# Altium schematic sheet Controller_Board_Interface.SchDoc(Controller_Board_Interface)
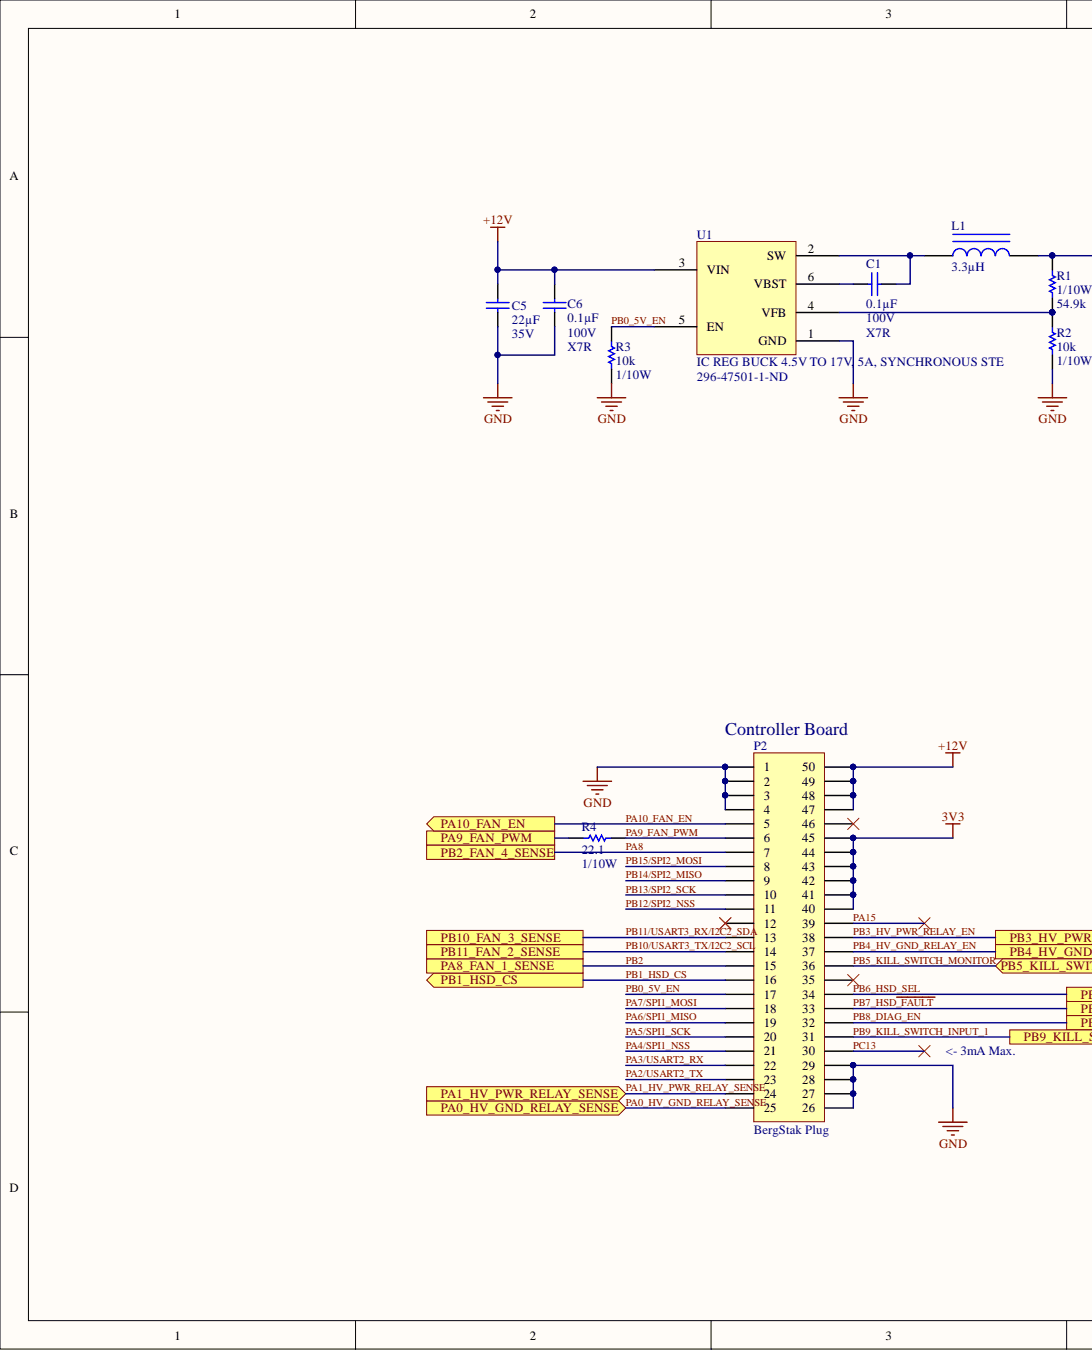
[diagram p0: Controller_Board_Interface.SchDoc, left]
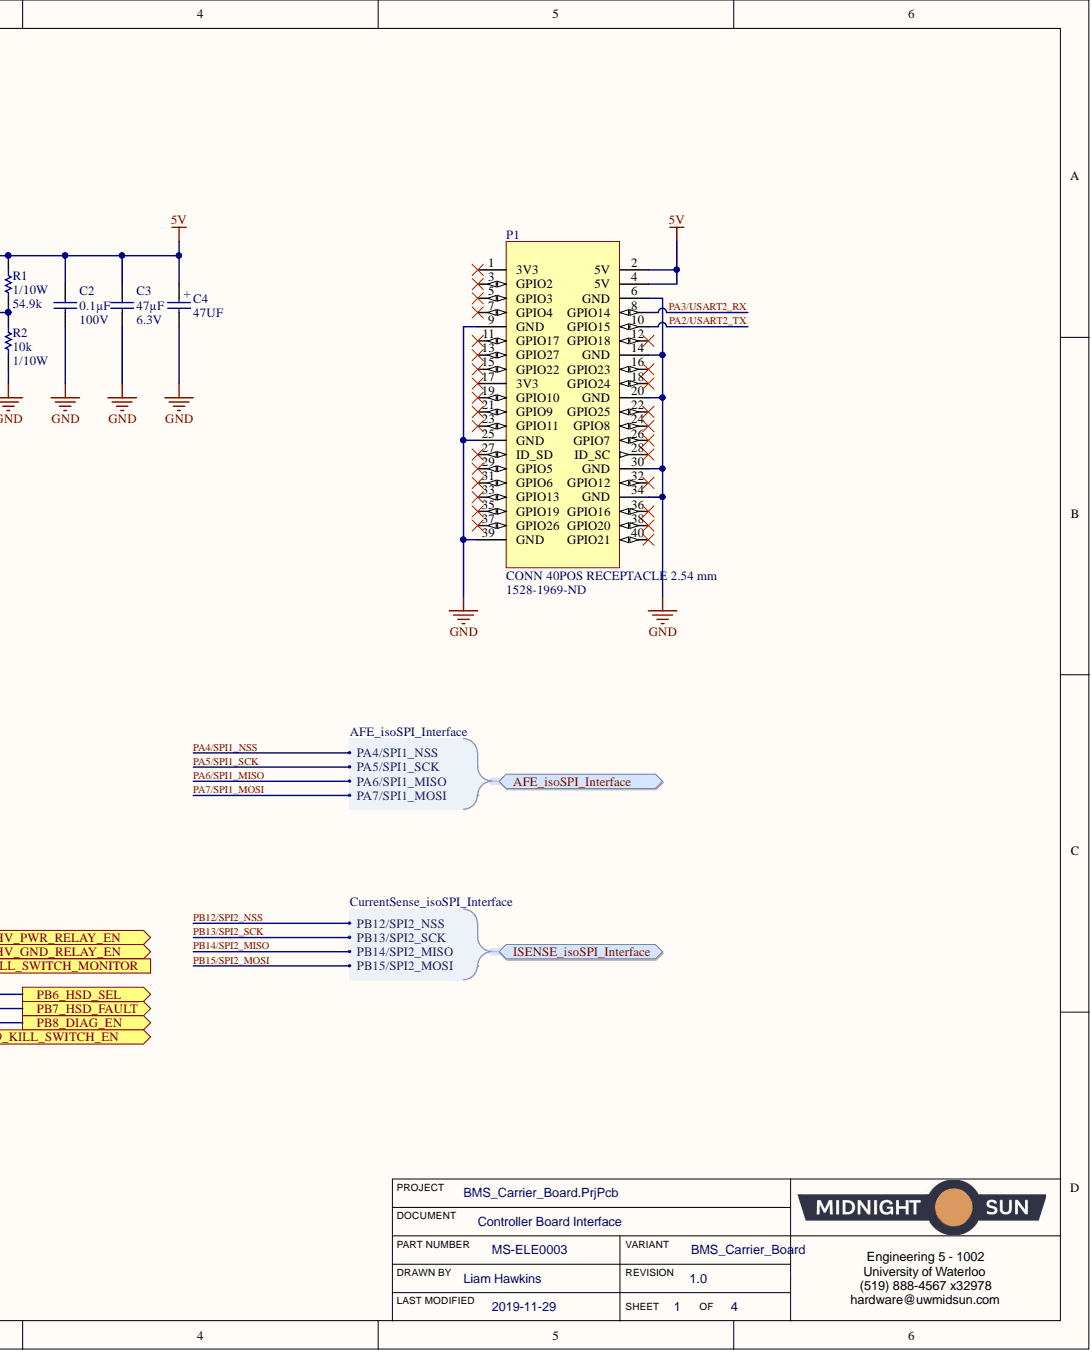
[diagram p1: Controller_Board_Interface.SchDoc, right]

## Parts
C1  Voltage: 100V  Capacitance: 0.1µF  [CAP, 0805]  (hidden comment: CAP CER 0.1UF 100V 10% X7R 0805)
C2  Voltage: 100V  Capacitance: 0.1µF  [CAP, 0805]  (hidden comment: CAP CER 0.1UF 100V 10% X7R 0805)
C3  Voltage Rating (DC): 6.3V  [1210]  (hidden comment: CAP CER 47UF 6.3V X7R 1210)
C4  47UF  [CAP ALUM 6.3MM RADIAL SMD]
C5  Voltage Rating (DC): 35V  [CAP, 0805]  (hidden comment: CAP CER 22UF 35V X5R 0805)
C6  Voltage: 100V  Temperature Coefficient: X7R  Capacitance: 0.1µF  [CAP, 0805]  (hidden comment: CAP CER 0.1UF 100V 10% X7R 0805)
L1  Inductance: 3.3µH  [IND 3.3UH 5.2A 20 MOHM SMD VLP8040]  (hidden comment: IND 3.3uH 5.2A 20MOHM SMD)
P1  CONN 40POS RECEPTACLE 2.54 mm  Supplier Part Number 1: 1528-1969-ND  [CONN 40POS RECEPTACLE 2.54 MM]
P2  Series: BergStak Plug  [CONN, Bergstak 50 Pos Plug]  (hidden comment: CONN 50POS Bergstak Plug 0.02")
R1  Resistance (Ohms): 54.9k  Power (Watts): 1/10W  [RES, 0603]  (hidden comment: RES 54.9K OHM 1% 1/10W 0603)
R2  Resistance: 10k  Power: 1/10W  [RES, 0603]  (hidden comment: RES 10K OHM 1% 1/10W 0603)
R3  Resistance: 10k  Power: 1/10W  [RES, 0603]  (hidden comment: RES 10K OHM 1% 1/10W 0603)
R4  Resistance: 22.1  Power: 1/10W  [RES, 0603]  (hidden comment: RES 22.1 OHM 1% 1/10W 0603)
U1  IC REG BUCK 4.5V TO 17V, 5A, SYNCHRONOUS STE  Supplier Part Number 1: 296-47501-1-ND  [IC REG BUCK 4.5V TO 17V, 5A]

## Ports
AFE_isoSPI_Interface, AFE_isoSPI_Interface.PA4/SPI1_NSS, AFE_isoSPI_Interface.PA5/SPI1_SCK, AFE_isoSPI_Interface.PA6/SPI1_MISO, AFE_isoSPI_Interface.PA7/SPI1_MOSI, ISENSE_isoSPI_Interface, ISENSE_isoSPI_Interface.PB12/SPI2_NSS, ISENSE_isoSPI_Interface.PB13/SPI2_SCK, ISENSE_isoSPI_Interface.PB14/SPI2_MISO, ISENSE_isoSPI_Interface.PB15/SPI2_MOSI, PA0_HV_GND_RELAY_SENSE, PA1_HV_PWR_RELAY_SENSE, PA8_FAN_1_SENSE, PA9_FAN_PWM, PA10_FAN_EN, PB1_HSD_CS, PB2_FAN_4_SENSE, PB3_HV_PWR_RELAY_EN, PB4_HV_GND_RELAY_EN, PB5_KILL_SWITCH_MONITOR, PB6_HSD_SEL, PB7_HSD~{_FAULT}, PB8_DIAG_EN, PB9_KILL_SWITCH_EN, PB10_FAN_3_SENSE, PB11_FAN_2_SENSE

## Nets
3V3: P2.40 P2.41 P2.42 P2.43 P2.44 P2.45
5V: C2.1 C3.1 C4.1 L1.2 P1.2(5V) P1.4(5V) R1.1
+12V: C5.1 C6.1 P2.47 P2.48 P2.49 P2.50 U1.3(VIN)
GND: C2.2 C3.2 C4.2 C5.2 C6.2 P1.6(GND) P1.9(GND) P1.14(GND) P1.20(GND) P1.25(GND) P1.30(GND) P1.34(GND) P1.39(GND) P2.1 P2.2 P2.3 P2.4 P2.26 P2.27 P2.28 P2.29 R2.2 R3.2 U1.1(GND)
PA0_HV_GND_RELAY_SENSE: P2.25 ; port PA0_HV_GND_RELAY_SENSE
PA1_HV_PWR_RELAY_SENSE: P2.24 ; port PA1_HV_PWR_RELAY_SENSE
PA2/USART2_TX: P1.10(GPIO15) P2.23
PA3/USART2_RX: P1.8(GPIO14) P2.22
PA4/SPI1_NSS: P2.21 ; port AFE_isoSPI_Interface
PA5/SPI1_SCK: P2.20 ; port AFE_isoSPI_Interface
PA6/SPI1_MISO: P2.19 ; port AFE_isoSPI_Interface
PA7/SPI1_MOSI: P2.18 ; port AFE_isoSPI_Interface
PA8: P2.7 ; port PB2_FAN_4_SENSE
PA9_FAN_PWM: P2.6 R4.2
PA10_FAN_EN: P2.5 ; port PA10_FAN_EN
PA15: P2.39
PB0_5V_EN: P2.17 R3.1 U1.5(EN)
PB1_HSD_CS: P2.16 ; port PB1_HSD_CS
PB2: P2.15 ; port PA8_FAN_1_SENSE
PB3_HV_PWR_RELAY_EN: P2.38 ; port PB3_HV_PWR_RELAY_EN
PB4_HV_GND_RELAY_EN: P2.37 ; port PB4_HV_GND_RELAY_EN
PB5_KILL_SWITCH_MONITOR: P2.36 ; port PB5_KILL_SWITCH_MONITOR
PB6_HSD_SEL: P2.34 ; port PB6_HSD_SEL
PB7_HSD~{_FAULT}: P2.33 ; port PB7_HSD~{_FAULT}
PB8_DIAG_EN: P2.32 ; port PB8_DIAG_EN
PB9_KILL_SWITCH_INPUT_1: P2.31 ; port PB9_KILL_SWITCH_EN
PB10/USART3_TX/I2C2_SCL: P2.14 ; port PB11_FAN_2_SENSE
PB11/USART3_RX/I2C2_SDA: P2.13 ; port PB10_FAN_3_SENSE
PB12/SPI2_NSS: P2.11 ; port ISENSE_isoSPI_Interface
PB13/SPI2_SCK: P2.10 ; port ISENSE_isoSPI_Interface
PB14/SPI2_MISO: P2.9 ; port ISENSE_isoSPI_Interface
PB15/SPI2_MOSI: P2.8 ; port ISENSE_isoSPI_Interface
PC13: P2.30
NetC1_1: C1.1 U1.6(VBST)
NetC1_2: C1.2 L1.1 U1.2(SW)
NetR1_2: R1.2 R2.1 U1.4(VFB)
NetR4_1: R4.1 ; port PA9_FAN_PWM

Not connected: P1 1(3V3) 3(GPIO2) 5(GPIO3) 7(GPIO4) 11(GPIO17) 12(GPIO18) 13(GPIO27) 15(GPIO22) 16(GPIO23) 17(3V3) 18(GPIO24) 19(GPIO10) 21(GPIO9) 22(GPIO25) 23(GPIO11) 24(GPIO8) 26(GPIO7) 27(ID_SD) 28(ID_SC) 29(GPIO5) 31(GPIO6) 32(GPIO12) 33(GPIO13) 35(GPIO19) 36(GPIO16) 37(GPIO26) 38(GPIO20) 40(GPIO21); P2 12 35 46

## Library data of the parts
C1 C2 C6 = CAP CER 0.1UF 100V 10% X7R 0805: 0.1µF ±10% 100V Ceramic Capacitor X7R 0805 (2012 Metric); Manufacturer Part Number 1: GCM21BR72A104KA37L; Manufacturer 1: Murata Electronics North America; Tolerance: ±10%; Thickness (Max): 0.055" (1.40mm); Supplier Part Number 1: 490-4789-1-ND; Supplier 1: Digi-Key; Size / Dimension: 0.079" L x 0.049" W (2.00mm x 1.25mm); Ratings: AEC-Q200; Part Status: Active; Packaging: Cut Tape (CT); Package / Case: 0805 (2012 Metric); Operating Temperature: -55°C ~ 125°C; Mounting Type: Surface Mount, MLCC; Lead Style: -; Lead Spacing: -; Height - Seated (Max): -; ComponentLink1URL: http://www.murata.com/~/media/webrenewal/support/library/catalog/products/capacitor/mlcc/c03e.ashx; ComponentLink1Description: http://www.murata.com/~/media/webrenewal/support/library/catalog/products/capacitor/mlcc/c03e.ashx; Component Kind: Standard; Library Name: Schematic Diagrams.SchLib
  C1 C2: Temperature Coefficient: X7R
C3 = CAP CER 47UF 6.3V X7R 1210: 47µF ±10% 6.3V Ceramic Capacitor X7R 1210 (3225 Metric); Manufacturer Part Number: GCJ32ER70J476KE01L; Manufacturer: Murata; Width: 0.098inch; Weight: 0.00388oz; Voltage Rating: 6.3V; Tolerance: 10%; Thickness: 0.11inch; Termination: SMD/SMT; Supplier Part Number 1: 490-10559-1-ND; Supplier 1: Digi-Key; Stock 1: 191399; RoHS: Yes; Ratings: AEC-Q200; Pricing 1: 1 = 1.53000, 10 = 1.21200, 100 = 0.90890, 500 = 0.68670 (USD); Packaging: Tape and Reel; Package Quantity: 1000; Mount: Surface Mount; Min Operating Temperature: -55°C; Max Operating Temperature: 125°C; Length: 0.126inch; Lead Free: Lead Free; Height: 2.5mm; Features: Soft Termination; Dielectric: X7R; Component Kind: Standard; Case Code (Metric): 3225; Case Code (Imperial): 1210; Case/Package: 1210; Capacitance: 47uF; Library Name: Schematic Diagrams.SchLib
C4 = CAP ALUM 47UF 20% 35V SMD: 47µF 35V Aluminum Electrolytic Capacitors Radial, Can - SMD 1000 Hrs @ 85°C; Manufacturer Part Number: EEE-1VA470WP; Manufacturer: Panasonic; Tolerance: 20%; Ripple Current (AC): 70mA; Life (Hours): 1000Hour; Voltage Rating (DC): 35V; Voltage Rating: 35V; Termination: SMD/SMT; Supplier Part Number 1: PCE3961CT-ND; Supplier 1: Digi-Key; Stock 1: 2820; Ripple Current: 70mA; REACH SVHC: Unknown; Radiation Hardening: No; Pricing 1: 1=0.38, 10=0.263, 100=0.1579, 500=0.11846 (USD); Packaging: Tape and Reel; Package Quantity: 1; Mount: Surface Mount,PCB; Min Operating Temperature: -40°C; Max Operating Temperature: 85°C; Length: 5.5mm; Lead Free: Lead Free; Height - Seated (Max): 0.213inch; Height: 5.4mm; Diameter: 6.3mm; Contact Plating: Tin; ComponentLink6URL: https://datasheet.ciiva.com/30206/11aba0000ce2-30206253.pdf; ComponentLink6Description: https://datasheet.ciiva.com/30206/11aba0000ce2-30206253.pdf; ComponentLink5URL: https://datasheet.ciiva.com/16507/aba0000ce2-16507965.pdf; ComponentLink5Description: https://datasheet.ciiva.com/16507/aba0000ce2-16507965.pdf; ComponentLink4URL: https://datasheet.ciiva.com/15165/getdatasheetpartid-86239-15165868.pdf; ComponentLink4Description: https://datasheet.ciiva.com/15165/getdatasheetpartid-86239-15165868.pdf; ComponentLink3URL: https://datasheet.ciiva.com/14598/70255710-14598937.pdf; ComponentLink3Description: https://datasheet.ciiva.com/14598/70255710-14598937.pdf; ComponentLink2URL: https://datasheet.ciiva.com/2983/aba0000ce2-2983927.pdf; ComponentLink2Description: https://datasheet.ciiva.com/2983/aba0000ce2-2983927.pdf; ComponentLink1URL: https://datasheet.ciiva.com/2983/aba0000ce2-2983926.pdf; ComponentLink1Description: https://datasheet.ciiva.com/2983/aba0000ce2-2983926.pdf; Component Kind: Standard; Case/Package: Radial; Capacitance: 47uF; Library Name: Schematic Diagrams.SchLib
C5 = CAP CER 22UF 35V X5R 0805: 22µF ±20% 35V Ceramic Capacitor X5R 0805 (2012 Metric); Manufacturer Part Number: C2012X5R1V226M125AC; Manufacturer: TDK; Width: 0.049inch; Weight: 0.000194oz; Voltage Rating: 35V; Tolerance: 20%; Thickness: 0.057inch; Termination: SMD/SMT; Supplier Part Number 1: 445-14428-1-ND; Supplier 1: Digi-Key; Stock 1: 30; RoHS: Yes; REACH SVHC: No SVHC; Pricing 1: 1 = 1.29000, 10 = 0.98100, 100 = 0.70190, 500 = 0.54338, 1000 = 0.46791 (USD); Packaging: Tape and Reel; Package Quantity: 2000; Mount: Surface Mount; Min Operating Temperature: -55°C; Max Operating Temperature: 85°C; Length: 0.079inch; Lead Free: Lead Free; Height: 1.25mm; Dielectric Material: Ceramic; Dielectric: X5R; ComponentLink1URL: https://product.tdk.com/info/en/catalog/spec/mlccspec_commercial_general_midvoltage_en.pdf; ComponentLink1Description: https://product.tdk.com/info/en/catalog/spec/mlccspec_commercial_general_midvoltage_en.pdf; Component Kind: Standard; Case Code (Metric): 2012; Case Code (Imperial): 0805; Case/Package: 0805; Capacitance: 22uF; Library Name: Schematic Diagrams.SchLib
L1 = IND 3.3uH 5.2A 20MOHM SMD: 3.3µH Shielded Wirewound Inductor 5.2A 20 mOhm Max Nonstandard; Manufacturer Part Number: VLS201612CX-3R3M; Manufacturer: TDK Corporation; Tolerance: ±20%; Test Frequency: 100kHz; Material - Core: Ferrite; Inductance Tolerance: 30%; Frequency - Test: 1MHz; DC Resistance (DCR): 170 mOhm Max; Current Rating: 1.37A; Current - Saturation: 1.04A; Width: 8mm; Type: Wirewound; Termination: SMD/SMT; Supplier Part Number 1: 445-6581-1-ND; Supplier Device Package: 2016; Supplier 1: Digi-Key; Stock 1: 8114; Size / Dimension: 0.079" L x 0.063" W (2.00mm x 1.60mm); Shielding: Shielded; Saturation Current: 5.2A; RoHS: RoHS Compliant; RMS Current (Irms): 5.2A; REACH SVHC: No SVHC; Q @ Freq: -; Pricing 1: 1 = 0.60000, 10 = 0.52700, 100 = 0.37660, 500 = 0.31636 (USD); Packaging: Cut Tape (CT); Package Quantity: 1; Package / Case: Nonstandard; Operating Temperature: -40°C ~ 105°C; Mounting Type: Surface Mount; Mount: Surface Mount; Min Operating Temperature: -40°C; Military Standard: Not; Max Operating Temperature: 105°C; Max DC Current: 5.2A; Length: 0.315inch; Lead Free: Lead Free; Height - Seated (Max): 0.047" (1.20mm); Height: 4mm; Frequency - Self Resonant: -; Depth: 8.7mm; Core Material: Ferrite; Composition: Wirewound; ComponentLink2URL: http://www.mouser.com/ds/2/400/nductor_commercial_power_vlp8040_en-952627.pdf; ComponentLink2Description: http://www.mouser.com/ds/2/400/nductor_commercial_power_vlp8040_en-952627.pdf; ComponentLink1URL: http://product.tdk.com/en/catalog/datasheets/inductor_commercial_power_vls-cx_en.pdf; ComponentLink1Description: http://product.tdk.com/en/catalog/datasheets/inductor_commercial_power_vls-cx_en.pdf; Component Kind: Standard; Category: Inductors, Coils, Chokes; Case/Package: Nonstandard; Library Name: Schematic Diagrams.SchLib
P1 = CONN 40POS RECEPTACLE 2.54 mm: GPIO HEADER FOR RASPBERRYPI A+/B; Manufacturer Part Number: 1992; Manufacturer: Adafruit Industries; Supplier 1: Digi-Key; Stock 1: 175; Pricing 1: 1=2.95 (USD); ComponentLink1URL: https://www.digikey.ca/product-detail/en/adafruit-industries-llc/1992/1528-1969-ND/6827092; ComponentLink1Description: gpio header raspberry pi; Component Kind: Standard; Library Name: Schematic Diagrams.SchLib
P2 = CONN 50POS Bergstak Plug 0.02": 50 Position Connector Plug, Outer Shroud Contacts Surface Mount Gold; Manufacturer Part Number 1: 10132797-055100LF; Manufacturer 1: Amphenol FCI; Pitch: 0.020" (0.50mm); Number of Positions: 50; Mated Stacking Heights: 5mm; Supplier Part Number 1: 609-5226-1-ND; Supplier 1: Digi-Key; Part Status: Active; Packaging: Cut Tape (CT); Number of Rows: 2; Mounting Type: Surface Mount; Height Above Board: 0.169" (4.30mm); Contact Finish Thickness: 1µin (0.025µm); Contact Finish: Gold; Connector Type: Plug, Outer Shroud Contacts; ComponentLink1URL: http://portal.fciconnect.com/Comergent//fci/drawing/10132797.pdf; ComponentLink1Description: http://portal.fciconnect.com/Comergent//fci/drawing/10132797.pdf; Component Kind: Standard; Library Name: Schematic Diagrams.SchLib
R1 = RES 54.9K OHM 1% 1/10W 0603: 54.9k Ohm ±1% 0.1W, 1/10W Chip Resistor 0603 (1608 Metric) Automotive AEC-Q200 Thick Film; Manufacturer Part Number: ERJ-3EKF5492V; Manufacturer: Panasonic Electronic Components; Temperature Coefficient: ±100ppm/°C; Tolerance: ±1%; Supplier Part Number 1: P54.9KHCT-ND; Supplier Device Package: 0603; Supplier 1: Digi-Key; Stock 1: 74038; Size / Dimension: 0.063" L x 0.031" W (1.60mm x 0.80mm); RoHS: RoHS Compliant; Pricing 1: 1=0.16, 10=0.031, 100=0.0127, 1000=0.00567, 2500=0.00492 (CAD); Part Status: Active; Packaging: Cut Tape (CT); Package / Case: 0603 (1608 Metric); Operating Temperature: -55°C ~ 155°C; Height - Seated (Max): 0.022" (0.55mm); Features: Automotive AEC-Q200; Failure Rate: -; Composition: Thick Film; ComponentLink1URL: https://industrial.panasonic.com/cdbs/www-data/pdf/RDA0000/AOA0000C298.pdf; ComponentLink1Description: https://industrial.panasonic.com/cdbs/www-data/pdf/RDA0000/AOA0000C298.pdf; Component Kind: Standard; Category: Resistors; Library Name: Schematic Diagrams.SchLib
R2 R3 = RES 10K OHM 1% 1/10W 0603: RES SMD 10K OHM 1% 1/10W 0603; Manufacturer Part Number 1: RC0603FR-0710KL; Manufacturer 1: Yageo; Temperature Coefficient: ±100ppm/°C; Tolerance: ±1%; Supplier Part Number 1: 311-10.0KHRCT-ND; Supplier Device Package: 0603; Supplier 1: Digi-Key; Size / Dimension: 0.063" L x 0.031" W (1.60mm x 0.80mm); Part Status: Active; Packaging: Cut Tape (CT); Package / Case: 0603 (1608 Metric); Operating Temperature: -55°C ~ 155°C; Height - Seated (Max): 0.022" (0.55mm); Failure Rate: -; Composition: Thick Film; ComponentLink1URL: http://www.yageo.com/documents/recent/PYu-RC_Group_51_RoHS_L_7.pdf; ComponentLink1Description: http://www.yageo.com/documents/recent/PYu-RC_Group_51_RoHS_L_7.pdf; Component Kind: Standard; Library Name: Schematic Diagrams.SchLib
R4 = RES 22.1 OHM 1% 1/10W 0603: 22.1 Ohm ±1% 0.1W, 1/10W Chip Resistor 0603 (1608 Metric) Moisture Resistant Thick Film; Manufacturer Part Number 1: RC0603FR-0722R1L; Manufacturer 1: Yageo; Temperature Coefficient: ±100ppm/°C; Tolerance: ±1%; Supplier Part Number 1: 311-22.1HRCT-ND; Supplier Device Package: 0603; Supplier 1: Digi-Key; Size / Dimension: 0.063" L x 0.031" W (1.60mm x 0.80mm); Part Status: Active; Packaging: Cut Tape (CT); Package / Case: 0603 (1608 Metric); Operating Temperature: -55°C ~ 155°C; Height - Seated (Max): 0.022" (0.55mm); Failure Rate: -; Composition: Thick Film; ComponentLink1URL: http://www.yageo.com/documents/recent/PYu-RC_Group_51_RoHS_L_7.pdf; ComponentLink1Description: http://www.yageo.com/documents/recent/PYu-RC_Group_51_RoHS_L_7.pdf; Component Kind: Standard; Library Name: Schematic Diagrams.SchLib
U1 = IC REG BUCK 4.5V TO 17V, 5A, SYNCHRONOUS STE: Buck Switching Regulator IC Positive Adjustable 0.6V 1 Output 5A SOT-23-6 Thin, TSOT-23-6; Manufacturer Part Number: TPS565201DDCT; Manufacturer: Texas Instruments; Supplier 1: Digi-Key; Stock 1: 2226; Pricing 1: 1 = 2.46000, 10 = 2.21100, 100 = 1.77710 (USD); Min Operating Temperature: -40°C; Max Operating Temperature: 125°C; Component Kind: Standard; Library Name: Schematic Diagrams.SchLib
